# Altium schematic sheet ADC_DAC_R1.1.SchDoc
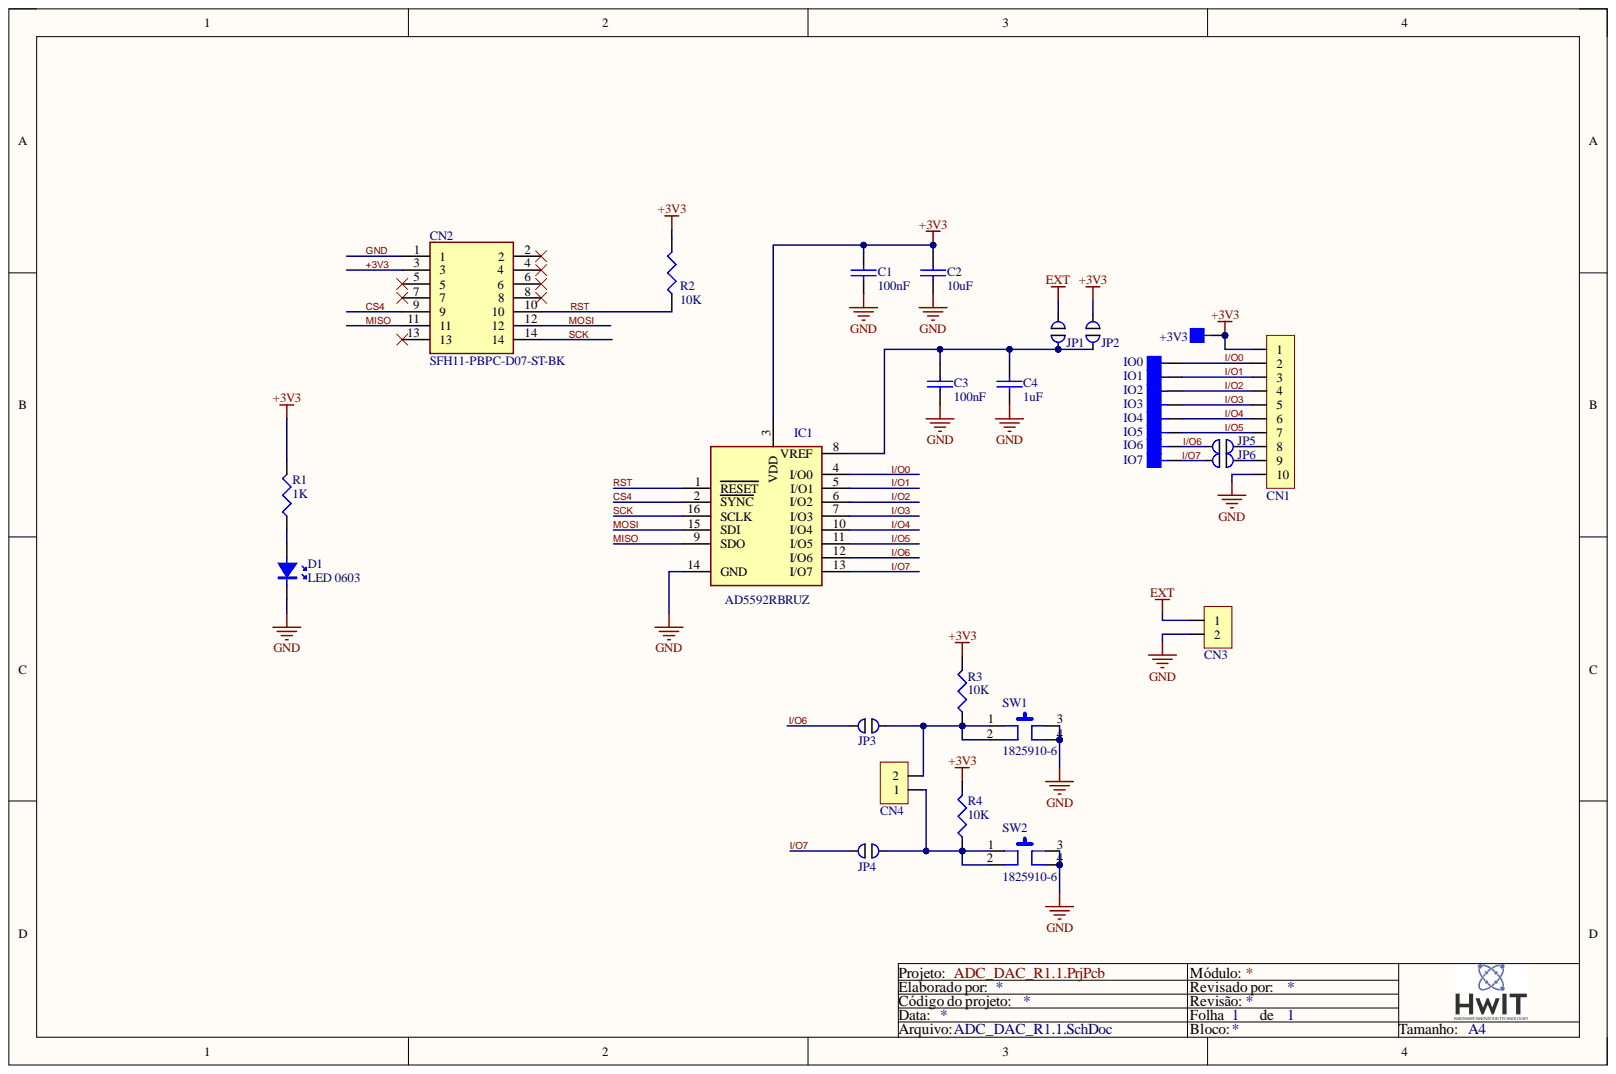
[diagram p0: ADC_DAC_R1.1.SchDoc]

## Parts
+3V3  TP60  [TP60]  (value not drawn)  (no BOM)
C1  100nF  [C0603]
C2  10uF  [C0603]
C3  100nF  [C0603]
C4  1uF  [C0603]
CN1  HD 1X10 HOR  [HEADER 10 VERT 2.54mm - SET]  (value not drawn)
CN2  SFH11-PBPC-D07-ST-BK  [SULLINS_SFH11-PBPC-D07-ST-BK]
CN3  HD 1X2 HOR  [HEADER2 VERT]  (value not drawn)
CN4  HD 1X2 HOR  [HEADER2 VERT]  (value not drawn)
D1  LED 0603  [LED0603]
IC1  AD5592RBRUZ  [SOP65P640X120-16N]
IO0  TP60  [TP60]  (value not drawn)  (no BOM)
IO1  TP60  [TP60]  (value not drawn)  (no BOM)
IO2  TP60  [TP60]  (value not drawn)  (no BOM)
IO3  TP60  [TP60]  (value not drawn)  (no BOM)
IO4  TP60  [TP60]  (value not drawn)  (no BOM)
IO5  TP60  [TP60]  (value not drawn)  (no BOM)
IO6  TP60  [TP60]  (value not drawn)  (no BOM)
IO7  TP60  [TP60]  (value not drawn)  (no BOM)
JP1  JUMPER CLOSER  [Jumper_OPEN]  (value not drawn)
JP2  JUMPER CLOSER  [Jumper_OPEN]  (value not drawn)
JP3  JUMPER CLOSER  [Jumper_OPEN]  (value not drawn)
JP4  JUMPER CLOSER  [Jumper_OPEN]  (value not drawn)
JP5  JUMPER CLOSER  [Jumper_OPEN]  (value not drawn)
JP6  JUMPER CLOSER  [Jumper_OPEN]  (value not drawn)
R1  1K  [R0603]
R2  10K  [R0603]
R3  10K  [R0603]
R4  10K  [R0603]
SW1  1825910-6  [18259106]
SW2  1825910-6  [18259106]

## Nets
EXT: CN3.1 JP1.1
+3V3: +3V3.1 C1.2 C2.1 CN1.1 CN2.3 IC1.3(VDD) JP2.2 R1.2 R2.2 R3.2 R4.2
CS4: CN2.9 IC1.2(SYNC)
GND: C1.1 C2.2 C3.1 C4.1 CN1.10 CN2.1 CN3.2 D1.K IC1.14(GND) SW1.3 SW1.4 SW2.3 SW2.4
I/O0: CN1.2 IC1.4(I/O0) IO0.1
I/O1: CN1.3 IC1.5(I/O1) IO1.1
I/O2: CN1.4 IC1.6(I/O2) IO2.1
I/O3: CN1.5 IC1.7(I/O3) IO3.1
I/O4: CN1.6 IC1.10(I/O4) IO4.1
I/O5: CN1.7 IC1.11(I/O5) IO5.1
I/O6: IC1.12(I/O6) IO6.1 JP3.2 JP5.2
I/O7: IC1.13(I/O7) IO7.1 JP4.2 JP6.2
MISO: CN2.11 IC1.9(SDO)
MOSI: CN2.12 IC1.15(SDI)
RST: CN2.10 IC1.1(RESET) R2.1
SCK: CN2.14 IC1.16(SCLK)
NetC3_2: C3.2 C4.2 IC1.8(VREF) JP1.2 JP2.1
NetCN1_8: CN1.8 JP5.1
NetCN1_9: CN1.9 JP6.1
NetCN4_1: CN4.1 JP4.1 R4.1 SW2.1 SW2.2
NetCN4_2: CN4.2 JP3.1 R3.1 SW1.1 SW1.2
NetD1_A: D1.A R1.1

Not connected: CN2 2 4 5 6 7 8 13

## Library data of the parts
+3V3 IO0-IO7 = TP60: Teste Point 60 mils; Library Name: Geral.SchLib
C1 C3 = __uF 0603: CAP CER 0.1UF 50V Y5V 0603; Manufacturer: YAGEO; Manufacturer PN: CC0603ZRY5V9BB104; Component Kind: Standard; Digi-Key Part Number: 311-1343-6-ND; Library Name: Capacitores.SchLib; Mouser Part Number: 603-C0603ZRY5V9BB104
C2 = __uF 0603: CAP CER 10UF 35V X5R 0603; Manufacturer: YAGEO; Manufacturer PN: CC0603MRX5RGBB106; Component Kind: Standard; Digi-Key Part Number: 13-CC0603MRX5RGBB106TR-ND; Library Name: Capacitores.SchLib; Mouser Part Number: CC0603MRX5RGBB106
C4 = __uF 0603: CAP CER 1UF 16V X7R 0603; Manufacturer: YAGEO; Manufacturer PN: CC0603KRX7R7BB105; Component Kind: Standard; Digi-Key Part Number: 311-1444-6-ND; Library Name: Capacitores.SchLib; Mouser Part Number: 603-CC603KRX5R7BB105
CN1 = HEADER 1x10 V 2.54MM: CONN HEADER 10POS VERT 2.5MM; Component Kind: Standard; Library Name: Conectores.SchLib
CN2 = SFH11-PBPC-D07-ST-BK: 14 Position Header Connector Through Hole; Manufacturer: Sullins Connector; Availability: Warning; Check_prices: https://www.snapeda.com/parts/SFH11-PBPC-D07-ST-BK/Sullins%20Connector%20Solutions/view-part/851415/?ref=eda; Component Kind: Standard; Library Name: Conectores.SchLib; Manufacturer PN: SFH11-PBPC-D07-ST-BK; Package: None; Price: None; Purchase-URL: https://pricing.snapeda.com/search/part/SFH11-PBPC-D07-ST-BK/?ref=eda; SnapEDA_Link: https://www.snapeda.com/parts/SFH11-PBPC-D07-ST-BK/Sullins%20Connector%20Solutions/view-part/851415/?ref=snap
CN3 CN4 = HEADER 1x2 v 2.54MM: CONN HEADER 2POS VERT 2.54MM; Component Kind: Standard; Library Name: Conectores.SchLib
D1 = LED 0603: LED BLUE CLEAR 0603 SMD; Manufacturer: Würth Elektronik; Manufacturer PN: 150060BS75000; Component Kind: Standard; Digi-Key Part Number: 732-4966-6-ND; Library Name: Diodos.SchLib; Mouser Part Number: 710-150060BS75000
IC1 = AD5592RBRUZ: IC ADC/DAC 12BIT 400K 16TSSOP; Manufacturer: Analog Devices; Arrow Part Number: AD5592RBRUZ; Arrow Price/Stock: https://www.arrow.com/en/products/ad5592rbruz/analog-devices?region=nac; Component Kind: Standard; Datasheet Link: https://www.analog.com/AD5592R/datasheet; Height: 1.2mm; Library Name: SamacSys.SchLib; Manufacturer PN: AD5592RBRUZ; Mouser Part Number: 584-AD5592RBRUZ; Mouser Price/Stock: https://www.mouser.co.uk/ProductDetail/Analog-Devices/AD5592RBRUZ?qs=wFnXgzJ2EGM0E%2FJNKZBaug%3D%3D
JP1-JP6 = JSO: Component Kind: Standard; Description: JUMPER CLOSER; Library Name: SamacSys.SchLib
R1 = __ R 0603 __ %: RES 1K OHM 1% 1/10W 0603; Manufacturer: YAGEO; Manufacturer PN: RC0603FR-071KL; Component Kind: Standard; Digi-Key Part Number: 311-1.00KHRDKR-ND; Mouser Part Number: 603-RC0603FR-071KL; Library Name: Resistores.SchLib
R2 = __ R 0603 __ %: RES SMD 10  KOHM 1% 1/10W 0603; Manufacturer: KOA Speer Electronics, Inc.; Manufacturer PN: RK73H1JTTP1002F; Component Kind: Standard; Digi-Key Part Number: 2019-RK73H1JTTP1002FCT-ND; Library Name: Resistores.SchLib
R3 R4 = __ R 0603 __ %: RES 10K OHM 1% 1/10W 0603; Manufacturer: YAGEO; Manufacturer PN: RC0603FR-0710KL; Component Kind: Standard; Digi-Key Part Number: 311-10.0KHRDKR-ND; Library Name: Resistores.SchLib
SW1 SW2 = 1825910-6: SWITCH TACTILE SPST-NO 0.05A 24V; Manufacturer: TE Connectivity; Arrow Part Number: 1825910-6; Arrow Price/Stock: https://www.arrow.com/en/products/1825910-6/te-connectivity?region=nac; Component Kind: Standard; Datasheet Link: https://www.te.com/commerce/DocumentDelivery/DDEController?Action=srchrtrv&DocNm=1308111-1_SWITCHES_CORE_PROGRAM_CATALOG&DocType=Catalog%20Section&DocLang=English&PartCntxt=1825910-6&DocFormat=pdf; Digi-key Part Number: 450-1650-ND; Library Name: SamacSys.SchLib; Manufacturer PN: 1825910-6; Mouser Part Number: 650-1825910-6; Mouser Price/Stock: https://www.mouser.co.uk/ProductDetail/TE-Connectivity/1825910-6?qs=A6cQTCBcXncisYDS1KbpGA%3D%3D
